# Altium schematic sheet 03_JTAG&SWD.SchDoc
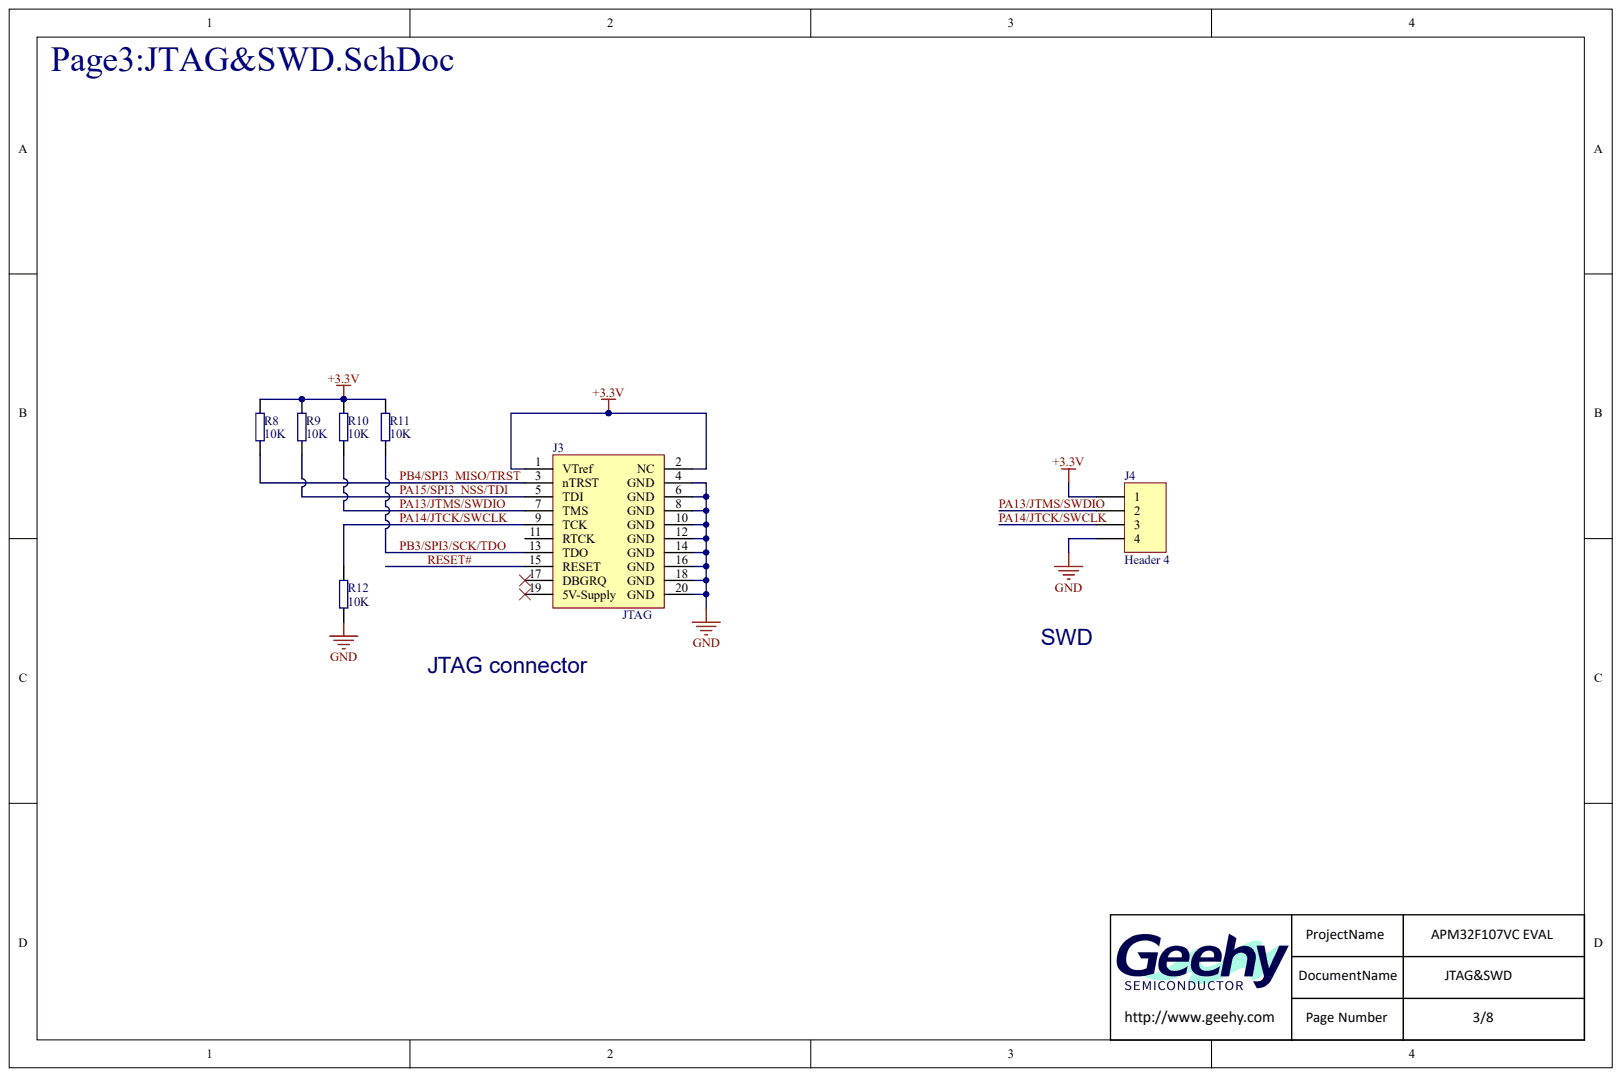
[diagram p0: 03_JTAG&SWD.SchDoc]

## Parts
J3  JTAG
J4  Header 4
R8  10K
R9  10K
R10  10K
R11  10K
R12  10K

## Nets
+3.3V: J3.1(VTref) J3.2(NC) J4.1 R8.1 R9.1 R10.1 R11.1
GND: J3.4(GND) J3.6(GND) J3.8(GND) J3.10(GND) J3.12(GND) J3.14(GND) J3.16(GND) J3.18(GND) J3.20(GND) J4.4 R12.2
PA13/JTMS/SWDIO: J3.7(TMS) J4.2 R10.2
PA14/JTCK/SWCLK: J3.9(TCK) J4.3 R12.1
PA15/SPI3_NSS/TDI: J3.5(TDI) R9.2
PB3/SPI3/SCK/TDO: J3.13(TDO) R11.2
PB4/SPI3_MISO/TRST: J3.3(nTRST) R8.2
RESET#: J3.15(RESET)

Not connected: J3 11(RTCK) 17(DBGRQ) 19(5V-Supply)

## Library data of the parts
J3 J4 R8-R12 = (no symbol name)
  J4: LatestRevisionDate: 17-Jul-2002; LatestRevisionNote: Re-released for DXP Platform.
  R8-R12: Published: 8-Jun-2000; LatestRevisionDate: 17-Jul-2002; LatestRevisionNote: Re-released for DXP Platform.; PackageReference: AXIAL-0.4
  J4 R8-R12: Publisher: Altium Limited
